# Altium schematic sheet SH1 - POWER.SchDoc
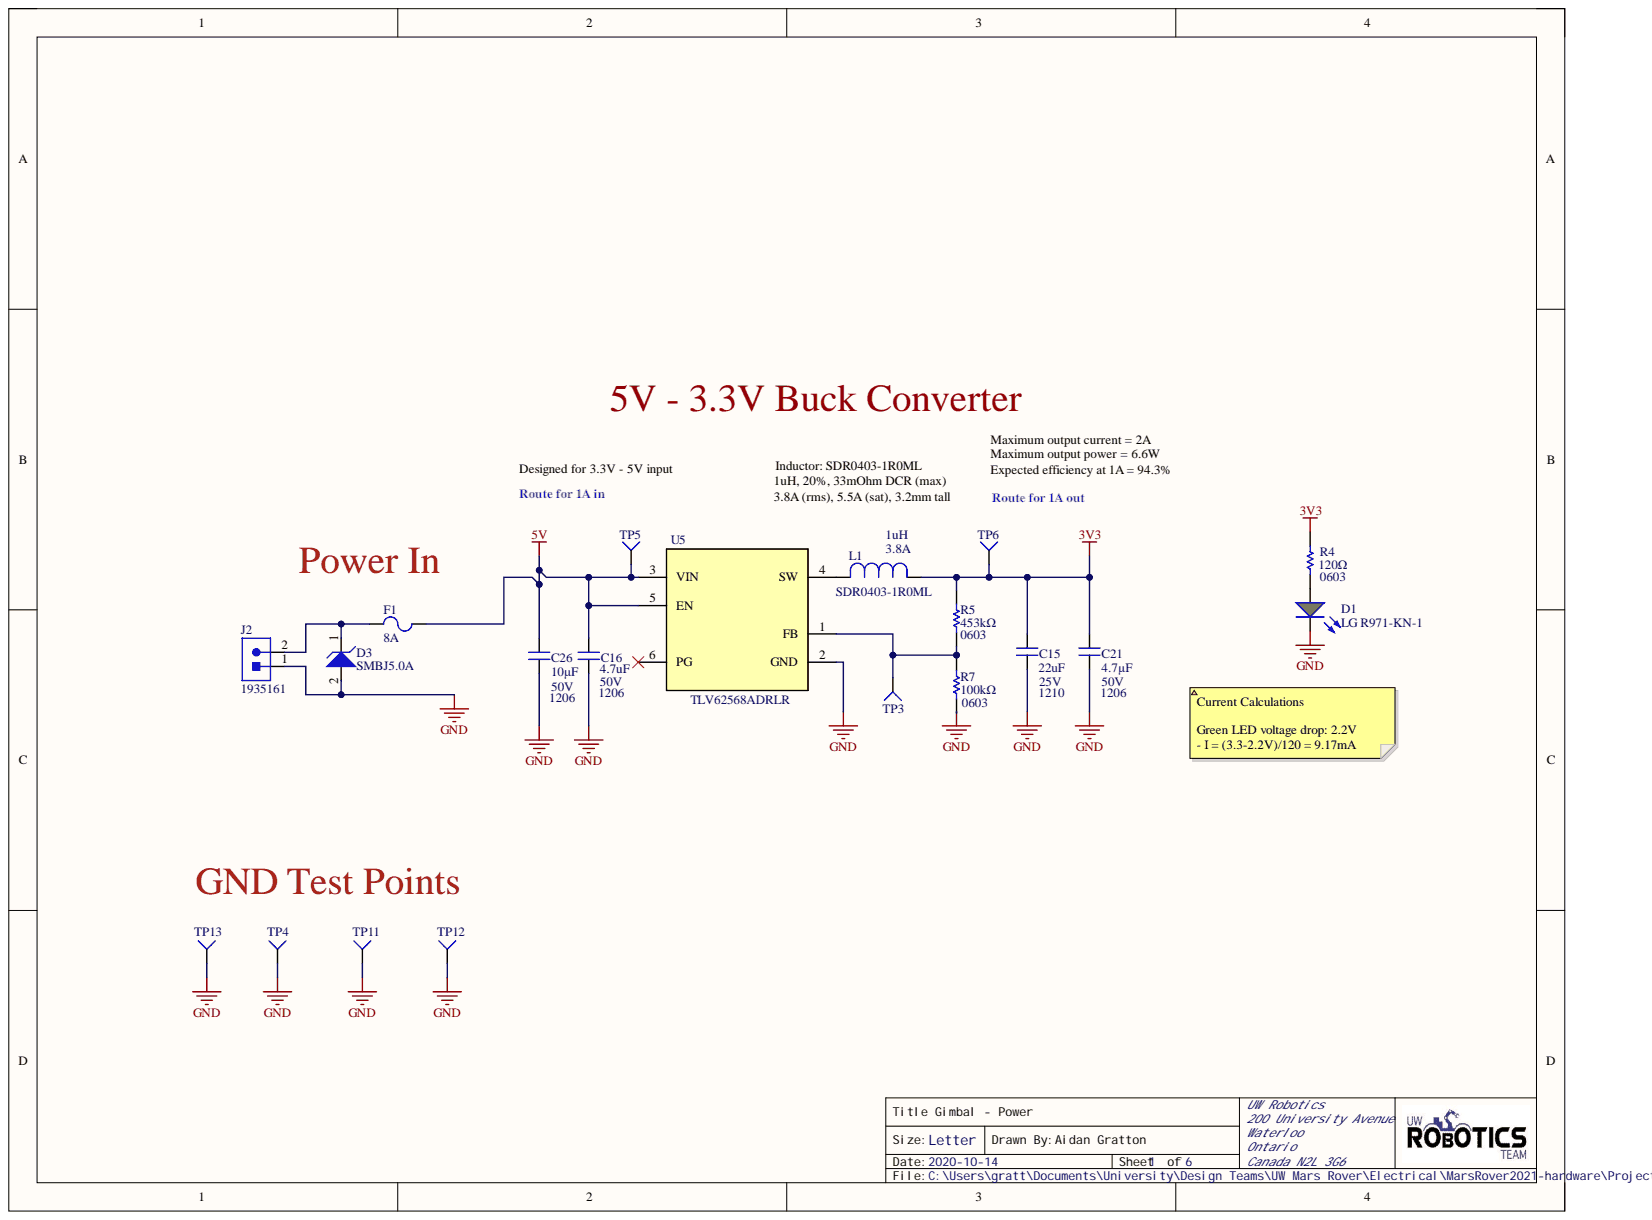
[diagram p0: SH1 - POWER.SchDoc]

## Parts
C15  Capacitance: 22uF  Package: 1210  Voltage: 25V  [CAPC3225X270N]  (hidden comment: GRM32ER71E226ME15K)
C16  Capacitance: 4.7uF  Case Code (Imperial): 1206  Voltage: 50V  [CAP1206]  (hidden comment: 4.7µF)
C21  4.7µF  Case Code (Imperial): 1206  Voltage: 50V  [CAP1206]
C26  value 10μF  Case Code (Imperial): 1206  Voltage Rating: 50V  [CAP1206]  (hidden comment: 10uF)
D1  LG R971-KN-1  [LED_0805_GREEN]
D3  TVS_DIODE_UNIDIR_5V  [SMBJ5.0A]  (value not drawn)
F1  Current: 8A  [FUSE_1206]  (hidden comment: FUSE_8A_1206)
J2  1935161  [1935161]
L1  value 1uH  Current Rating: 3.8A  Manufacturer Part Number 1: SDR0403-1R0ML  [IND_BOURNS_SDR04]  (hidden comment: IND_1uH_3.8A)
R4  value 120Ω  [RESC0603(1608)_N]  (hidden comment: RES_120Ω_0603)
R5  value 453kΩ  Case Code (Imperial): 0603  [RESC0603(1608)_N]  (hidden comment: RES_453kΩ_0603)
R7  value 100kΩ  Case Code (Imperial): 0603  [RESC0603(1608)_N]  (hidden comment: RES_100kΩ_0603_1%)
TP3  TESTPOINT_1MM_CIRCLE  [TESTPOINT_CIRCLE_1MM]  (value not drawn)
TP4  TESTPOINT_1MM_SQUARE  [TESTPOINT_SQUARE_1MM]  (value not drawn)
TP5  TESTPOINT_SMD_LOOP  [S1751-46R]  (value not drawn)
TP6  TESTPOINT_SMD_LOOP  [S1751-46R]  (value not drawn)
TP11  TESTPOINT_1MM_SQUARE  [TESTPOINT_SQUARE_1MM]  (value not drawn)
TP12  TESTPOINT_1MM_SQUARE  [TESTPOINT_SQUARE_1MM]  (value not drawn)
TP13  TESTPOINT_SMD_LOOP  [S1751-46R]  (value not drawn)
U5  TLV62568ADRLR  [SOT50P160X60-6N]

## Nets
3V3: C15.2 C21.2 L1.2 R4.2 R5.2 TP6.1
5V: C16.2 C26.2 F1.2 TP5.1 U5.3(VIN) U5.5(EN)
GND: C15.1 C16.1 C21.1 C26.1 D1.2 D3.2 J2.1 R7.1 TP4.1 TP11.1 TP12.1 TP13.1 U5.2(GND)
NetD1_1: D1.1 R4.1
NetD3_1: D3.1 F1.1 J2.2
NetL1_1: L1.1 U5.4(SW)
NetR5_1: R5.1 R7.2 TP3.1 U5.1(FB)

Not connected: U5 6(PG)

## Library data of the parts
C15 = GRM32ER71E226ME15K: CAP CER 22UF 25V X7R 1210; Manufacturer 1: Murata Electronics; Tolerance: ±20%; Component Kind: Standard; Manufacturer Part Number 1: GRM32ER71E226ME15K; Supplier 1: Digi-Key; Supplier Part Number 1: 490-14682-1-ND; Temperature Coefficient: X7R; Library Name: UWRT_Schematic_Library_2020.SchLib
C16 C21 = CAP_4.7µF_50V_1206: CAP CER 4.7UF 50V X7R 1206; Manufacturer 1: Murata; Manufacturer Part Number 1: GRM31CR71H475KA12L; Material: Ceramic; Tolerance: 10%; Case Code (Metric): 3216; Component Kind: Standard; ComponentLink7Description: https://datasheet.ciiva.com/6270/partnumbering-e-01-6270217.pdf?src-supplier=Digi-Key; ComponentLink7URL: https://datasheet.ciiva.com/6270/partnumbering-e-01-6270217.pdf?src-supplier=Digi-Key; ComponentLink8Description: https://datasheet.ciiva.com/6270/partnumbering-e-01-6270218.pdf?src-supplier=Digi-Key; ComponentLink8URL: https://datasheet.ciiva.com/6270/partnumbering-e-01-6270218.pdf?src-supplier=Digi-Key; Depth: 1.6mm; Dielectric: X7R; Height: 1.6mm; Length: 3.2mm; Max Operating Temperature: 125°C; Min Operating Temperature: -55°C; Mount: Surface Mount; Package: 1206 (3216 Metric); REACH SVHC: No SVHC; Supplier 1: Digi-Key; Supplier Part Number 1: 490-6521-1-ND; Termination: SMD/SMT; Thickness: 0.071inch; Voltage Rating: 50V; Voltage Rating (DC): 50V; Width: 0.063inch; Library Name: UWRT_Schematic_Library_2020.SchLib
  C21: Capacitance: 4.7uF
C26 = CAP_10uF_50V_1206: CAP CER 10UF 50V X5R 1206; Manufacturer 1: Murata; Manufacturer Part Number 1: GRT31CR61H106KE01L; Case Code (Metric): 3216; Component Kind: Standard; Dielectric: X5R; Dimensions: 0.126" L x 0.063" W (3.20mm x 1.6mm); Manufacturer Part Numer 1: GRT31CR61H106KE01L; Mount: Surface Mount; Package: 1206 (3216 Metric); Signal Integrity: Cap; Simulation: CAP; Supplier 1: Digi-Key; Supplier Part Number 1: 490-12456-1-ND; Library Name: UWRT_Schematic_Library_2020.SchLib
D1 = DIO_LED_G: LED GREEN DIFFUSED 0805 SMD; Manufacturer 1: OSRAM; Forward Voltage Drop: 2.2V; Component Kind: Standard; Manufacturer Part Number 1: LG R971-KN-1; Mount: Surface Mount; Package: 0805 (2012 Metric); Supplier 1: Digi-Key; Supplier Part Number 1: 475-1410-1-ND; Library Name: UWRT_Schematic_Library_2020.SchLib
D3 = TVS_DIODE_UNIDIR_5V: TVS DIODE 5V 9.2V DO214AA; Manufacturer 1: Bourns; Breakdown Voltage: 7.25V; Direction: Unidirectional; Element Configuration: Single; ESD Protection: Yes; Leakage Current: 800uA; Max Power Dissipation: 600W; Max Surge Current: 100A; Min Breakdown Voltage: 6.4V; Number of Channels: 1; Operating Supply Voltage: 3.5V; Peak Pulse Current: 65.2A; Power Line Protection: No; Test Current: 10mA; Case/Package: SMB; Clamping Voltage: 9.2V; Component Kind: Standard; ComponentLink1Description: https://datasheet.ciiva.com/25624/0900766b81122151-25624859.pdf?src-supplier=RSComponents; ComponentLink1URL: https://datasheet.ciiva.com/25624/0900766b81122151-25624859.pdf?src-supplier=RSComponents; ComponentLink2Description: https://datasheet.ciiva.com/5749/1677318-5749456.pdf?src-supplier=Farnell; ComponentLink2URL: https://datasheet.ciiva.com/5749/1677318-5749456.pdf?src-supplier=Farnell; ComponentLink3Description: https://datasheet.ciiva.com/334/smbj-334066.pdf?src-supplier=Digi-Key; ComponentLink3URL: https://datasheet.ciiva.com/334/smbj-334066.pdf?src-supplier=Digi-Key; ComponentLink4Description: https://datasheet.ciiva.com/17413/smbj-17413462.pdf?src-supplier=Avnet+Europe; ComponentLink4URL: https://datasheet.ciiva.com/17413/smbj-17413462.pdf?src-supplier=Avnet+Europe; ComponentLink5Description: https://datasheet.ciiva.com/3021/smbj-3021451.pdf?src-supplier=Avnet; ComponentLink5URL: https://datasheet.ciiva.com/3021/smbj-3021451.pdf?src-supplier=Avnet; ComponentLink6Description: https://datasheet.ciiva.com/3021/smbj-3021452.pdf?src-supplier=Avnet; ComponentLink6URL: https://datasheet.ciiva.com/3021/smbj-3021452.pdf?src-supplier=Avnet; ComponentLink8Description: https://datasheet.ciiva.com/30094/smbj-30094061.pdf?src-supplier=Onlinecomponents.com; ComponentLink8URL: https://datasheet.ciiva.com/30094/smbj-30094061.pdf?src-supplier=Onlinecomponents.com; ComponentLink9Description: https://datasheet.ciiva.com/334/smbj-334064.pdf?src-supplier=Digi-Key; ComponentLink9URL: https://datasheet.ciiva.com/334/smbj-334064.pdf?src-supplier=Digi-Key; ComponentLink10Description: https://datasheet.ciiva.com/334/smbj-334065.pdf?src-supplier=Digi-Key; ComponentLink10URL: https://datasheet.ciiva.com/334/smbj-334065.pdf?src-supplier=Digi-Key; ComponentLink11Description: https://datasheet.ciiva.com/4510/smbj-51809-4510005.pdf?src-supplier=Mouser; ComponentLink11URL: https://datasheet.ciiva.com/4510/smbj-51809-4510005.pdf?src-supplier=Mouser; ComponentLink12Description: https://datasheet.ciiva.com/8031/1677318-8031160.pdf?src-supplier=Element14; ComponentLink12URL: https://datasheet.ciiva.com/8031/1677318-8031160.pdf?src-supplier=Element14; ComponentLink13Description: https://datasheet.ciiva.com/48712/001056320-da-01-en-tvs-diode-smbj5-0a-do-214aa-bou-48712572.pdf?src-supplier=Conrad; ComponentLink13URL: https://datasheet.ciiva.com/48712/001056320-da-01-en-tvs-diode-smbj5-0a-do-214aa-bou-48712572.pdf?src-supplier=Conrad; Composition: Zener; Height: 2.42mm; Length: 4.57mm; Manufacturer Part Number 1: SMBJ5.0A; Max Breakdown Voltage: 7.25V; Max Operating Temperature: 150°C; Max Reverse Leakage Current: 800uA; Min Operating Temperature: -55°C; Mount: Surface Mount; Number of Elements: 1; Number of Pins: 2; Number of Unidirectional Channels: 1; Package Quantity: 1; Packaging: Tape and Reel; Peak Pulse Power: 600W; Polarity: Unidirectional; Pricing 1: 1=0.39000, 10=0.29300, 25=0.26440, 100=0.13950, 250=0.13804, 500=0.12362, 1000=0.09620 (USD); Radiation Hardening: No; REACH SVHC: No SVHC; Reverse Breakdown Voltage: 6.4V; Reverse Standoff Voltage: 5V; RoHS: Yes; Stock 1: 3797; Supplier 1: Digi-Key; Supplier Part Number 1: SMBJ5.0ABCT-ND; Termination: SMD/SMT; Width: 3.94mm; Library Name: UWRT_Schematic_Library_2020.SchLib
F1 = FUSE_8A_1206: FUSE SMD 8A 24V; Manufacturer 1: Littelfuse; Manufacturer Part Number 1: 0469008.WR; Approvals: UL,CSA; DC Cold Resistance: 31mR; Fuse Type: Board Mount (Cartridge Style Excluded); Power Dissipation: 157.5mW; Case Code (Imperial): 1206; Case Code (Metric): 3216; Case/Package: 1206; Component Kind: Standard; Current Rating: 8A; Depth: 1.52mm; Height: 0.023inch; Housing Material: Ceramic; Length: 3.18mm; Max Operating Temperature: 90°C; Min Operating Temperature: -55°C; Mount: Surface Mount; Supplier 1: Digi-Key; Supplier Part Number 1: F3542CT-ND; Voltage Rating (AC): 24V; Voltage Rating (DC): 24V; Width: 0.06inch; Library Name: UWRT_Schematic_Library_2020.SchLib
J2 = CON_2M_TERM: TERM BLK 2POS SIDE ENTRY 5MM; Manufacturer 1: Phoenix Contact; Approvals: UL; Connector Type: Screw; Current: 18A; Current Rating: 17.5A; Max Current Rating: 16A; Max Supply Voltage: 250V; Number of Circuits: 2; Number of Levels: 1; Voltage: 300V; Voltage Rating (DC): 320V; Wire Gauge (Max): 26AWG; Wire Gauge (Min): 12AWG; Color: Green; Component Kind: Standard; Contact Material: Copper,Tin; Depth: 9mm; Flammability Rating: UL94 V-0; Height: 11.3mm; Housing Color: Green; Housing Material: Polyamide; Insulation Material: Nylon; Length: 9mm; Manufacturer Part Number 1: 1935161; Material: Nylon; Mount: Through Hole; Number of Contacts: 2; Number of Pins: 2; Number of Poles: 2; Number of Positions: 2; Number of Positions Per Level: 2; Number of Rows: 1; Orientation: Straight; Pitch: 5mm; REACH SVHC: Unknown; Supplier 1: Digi-Key; Supplier Part Number 1: 277-1667-ND; Termination: Solder; Voltage Rating: 250V; Weight: 0.067021oz; Width: 14.9mm; Wire/Cable Gauge: 26AWG; Library Name: UWRT_Schematic_Library_2020.SchLib
L1 = IND_1uH_3.8A: FIXED IND 1UH 3.8A 33 MOHM SMD; Manufacturer 1: Bourns Inc.; Tolerance: ±20%; Component Kind: Standard; Supplier 1: Digi-Key; Supplier Part Number 1: SDR0403-1R0MLCT-ND; Library Name: UWRT_Schematic_Library_2020.SchLib
R4 = RES_120Ω_0603: RES SMD 120 OHM 5% 1/10W 0603; Manufacturer 1: Yageo; Manufacturer Part Number 1: RC0603JR-07120RL; Case Code (Imperial): 0603; Case Code (Metric): 1608; Component Kind: Standard; Dimensions: 0.063" L x 0.031" W (1.60mm x 0.80mm); Mount: Surface Mount; Package: 0603 (1608 Metric); Signal Integrity: Res; Simulation: RESISTOR; Supplier 1: Digi-Key; Supplier Part Number 1: 311-120GRCT-ND; Tolerance: 5%; Library Name: UWRT_Schematic_Library_2020.SchLib
R5 = RES_453kΩ_0603: RES SMD 453K OHM 1% 1/10W 0603; Manufacturer 1: Panasonic Electronic Components; Manufacturer Part Number 1: ERJ-3EKF4533V; Case Code (Metric): 1608; Component Kind: Standard; Dimensions: 0.063" L x 0.031" W (1.60mm x 0.80mm); Mount: Surface Mount; Package: 0603 (1608 Metric); Signal Integrity: Res; Simulation: RESISTOR; Supplier 1: Digi-Key; Supplier Part Number 1: P453KHCT-ND; Tolerance: 1%; Library Name: UWRT_Schematic_Library_2020.SchLib
R7 = RES_100kΩ_0603_1%: RES SMD 100K OHM 1% 1/10W 0603; Manufacturer 1: Yageo; Manufacturer Part Number 1: RC0603FR-07100KL; Max Power Dissipation: 125mW; Resistance: 10.2kR; Temperature Coefficient: 100ppm/°C; Voltage Rating: 150V; Case Code (Metric): 1608; Case/Package: 0805; Component Kind: Standard; ComponentLink7Description: https://datasheet.ciiva.com/22702/1697337-22702902.pdf?src-supplier=Element14; ComponentLink7URL: https://datasheet.ciiva.com/22702/1697337-22702902.pdf?src-supplier=Element14; ComponentLink8Description: https://datasheet.ciiva.com/16204/dcrcwe3-16204135.pdf?src-supplier=Future+Electronics; ComponentLink8URL: https://datasheet.ciiva.com/16204/dcrcwe3-16204135.pdf?src-supplier=Future+Electronics; ComponentLink9Description: https://datasheet.ciiva.com/16852/dcrcwe3-16852825.pdf?src-supplier=Avnet+Europe; ComponentLink9URL: https://datasheet.ciiva.com/16852/dcrcwe3-16852825.pdf?src-supplier=Avnet+Europe; ComponentLink10Description: https://datasheet.ciiva.com/7663/1697337-7663915.pdf?src-supplier=Element14; ComponentLink10URL: https://datasheet.ciiva.com/7663/1697337-7663915.pdf?src-supplier=Element14; ComponentLink11Description: https://datasheet.ciiva.com/7663/1697337-7663916.pdf?src-supplier=Element14; ComponentLink11URL: https://datasheet.ciiva.com/7663/1697337-7663916.pdf?src-supplier=Element14; ComponentLink12Description: https://datasheet.ciiva.com/22674/1825492-22674606.pdf?src-supplier=Element14; ComponentLink12URL: https://datasheet.ciiva.com/22674/1825492-22674606.pdf?src-supplier=Element14; ComponentLink13Description: https://datasheet.ciiva.com/3258/dcrcwe3-3258031.pdf?src-supplier=Avnet; ComponentLink13URL: https://datasheet.ciiva.com/3258/dcrcwe3-3258031.pdf?src-supplier=Avnet; Composition: Thick Film; Contact Plating: Tin; Depth: 1.25mm; Dimensions: 0.063" L x 0.031" W (1.60mm x 0.80mm); Features: Automotive AEC-Q200; Height: 0.45mm; Length: 2mm; Manufacturer 2: Vishay; Manufacturer Part Number 2: CRCW080510K2FKEA; Max Operating Temperature: 155°C; Military Standard: Not; Min Operating Temperature: -55°C; Mount: Surface Mount; Number of Pins: 2; Number of Terminations: 2; Package: 0603 (1608 Metric); Power Rating: 125mW; Pricing 2: 1=0.10000, 10=0.06200, 100=0.02540, 1000=0.01142, 2500=0.00991 (USD); REACH SVHC: Unknown; Signal Integrity: Res; Simulation: RESISTOR; Stock 2: 7147; Supplier 1: Digi-Key; Supplier 2: Digi-Key; Supplier Part Number 1: 311-100KHRCT-ND; Supplier Part Number 2: 541-10.2KCCT-ND; Termination: SMD/SMT; Tolerance: 1%; Voltage Rating (DC): 150V; Weight: 0.000194oz; Width: 0.049inch; Working Voltage: 150V; Library Name: UWRT_Schematic_Library_2020.SchLib
TP3 = TESTPOINT_1MM_CIRCLE: TESTPOINT 1MM CIRCLE; Component Kind: Standard; Library Name: UWRT_Schematic_Library_2020.SchLib
TP4 TP11 TP12 = TESTPOINT_1MM_SQUARE: TESTPOINT 1MM SQUARE; Component Kind: Standard; Library Name: UWRT_Schematic_Library_2020.SchLib
TP5 TP6 TP13 = TESTPOINT_SMD_LOOP: PC TEST POINT SMD; Manufacturer 1: Harwin; Number of Contacts: 1; Plating: Tin; Category: Terminal Blocks; Color: Natural; Component Kind: Standard; ComponentLink1Description: https://datasheet.ciiva.com:443/pdfs/VipMasterIC/IC/HRWN/HRWN-S-A0002541722/HRWN-S-A0004513972-1.pdf?src-supplier=IHS+Markit; ComponentLink1URL: https://datasheet.ciiva.com:443/pdfs/VipMasterIC/IC/HRWN/HRWN-S-A0002541722/HRWN-S-A0004513972-1.pdf?src-supplier=IHS+Markit; ComponentLink2Description: https://datasheet.ciiva.com/5224/2010page161-58228-5224784.pdf?src-supplier=Mouser; ComponentLink2URL: https://datasheet.ciiva.com/5224/2010page161-58228-5224784.pdf?src-supplier=Mouser; ComponentLink3Description: https://datasheet.ciiva.com/22631/1688119-22631153.pdf?src-supplier=Element14; ComponentLink3URL: https://datasheet.ciiva.com/22631/1688119-22631153.pdf?src-supplier=Element14; ComponentLink4Description: https://datasheet.ciiva.com/2917/s1751-2917604.pdf?src-supplier=Digi-Key; ComponentLink4URL: https://datasheet.ciiva.com/2917/s1751-2917604.pdf?src-supplier=Digi-Key; ComponentLink5Description: https://datasheet.ciiva.com/1462/1693781-1462652.pdf?src-supplier=Farnell; ComponentLink5URL: https://datasheet.ciiva.com/1462/1693781-1462652.pdf?src-supplier=Farnell; ComponentLink6Description: https://datasheet.ciiva.com/1454/1693781-1454941.pdf?src-supplier=Element14; ComponentLink6URL: https://datasheet.ciiva.com/1454/1693781-1454941.pdf?src-supplier=Element14; Contact Material: Copper,Copper Alloy; Contact Plating: Tin; Depth: 2mm; Height: 2mm; Length: 3.25mm; Manufacturer Part Number 1: S1751-46R; Material: Brass,Copper Alloy; Mount: Surface Mount; Number of Ports: 1; Orientation: Straight; Package Quantity: 2500; Packaging: Tape and Reel; Pricing 1: 1=0.29000, 10=0.27700, 25=0.23760, 50=0.20200, 100=0.19400, 250=0.17424, 500=0.16632, 1000=0.13860 (USD); Radiation Hardening: No; REACH SVHC: No SVHC; RoHS: Yes; Stock 1: 159143; Supplier 1: Digi-Key; Supplier Part Number 1: 952-1478-1-ND; Termination: Solder; Width: 1.65mm; Library Name: UWRT_Schematic_Library_2020.SchLib
U5 = TLV62568ADRLR: IC REG BUCK ADJUSTABLE 2A SOT563; Manufacturer 1: Texas Instruments; Iout: 1A; Switching Frequency: 1.5MHz; Vin (Max): 5.5V; Vin (Min): 2.5V; Vout (Min/Fixed): 0.6V; Component Kind: Standard; Iout (Max): 2A; Manufacturer Part Number 1: TLV62568ADRLR; Package: SOT-563, SOT-666; Supplier 1: Digi-Key; Supplier Part Number 1: 296-50407-1-ND; Vout (Max): 5.5V; Library Name: UWRT_Schematic_Library_2020.SchLib
